# Altium schematic sheet [03] - 28PINS SCHEMATIC.SchDoc("[03] - 28PINS SCHEMATIC")
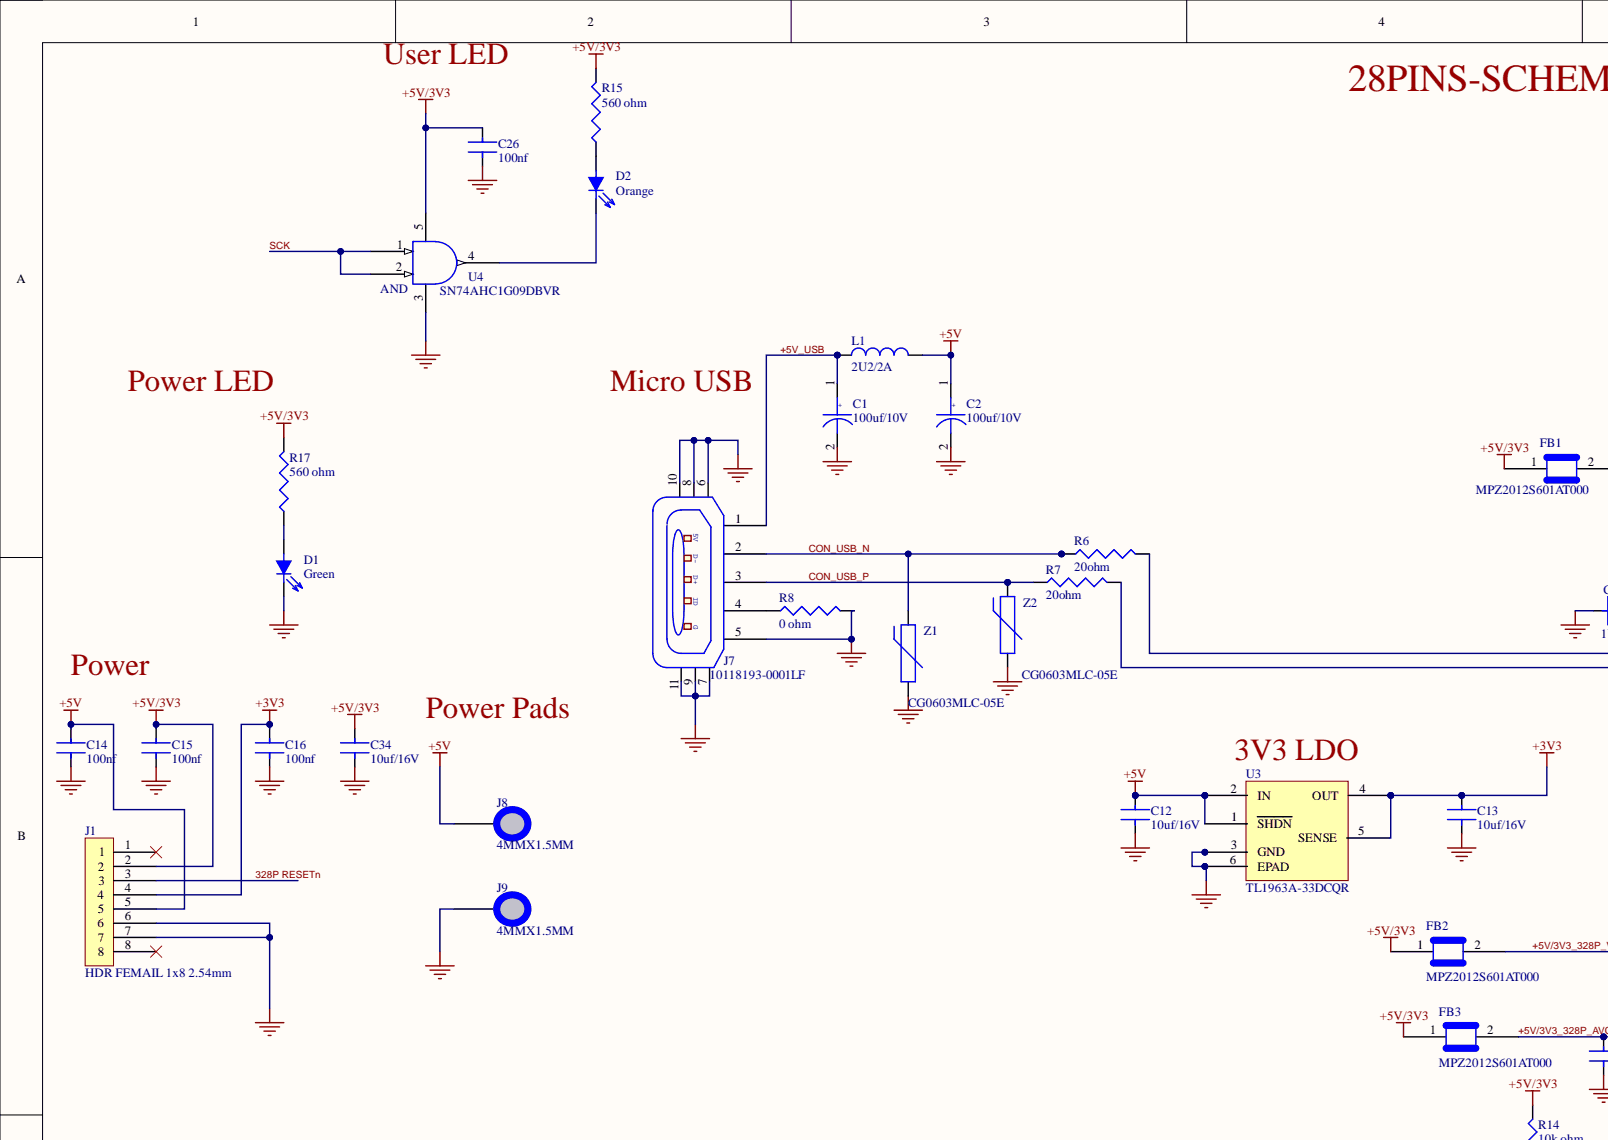
[diagram p0: [03] - 28PINS SCHEMATIC.SchDoc, top left]
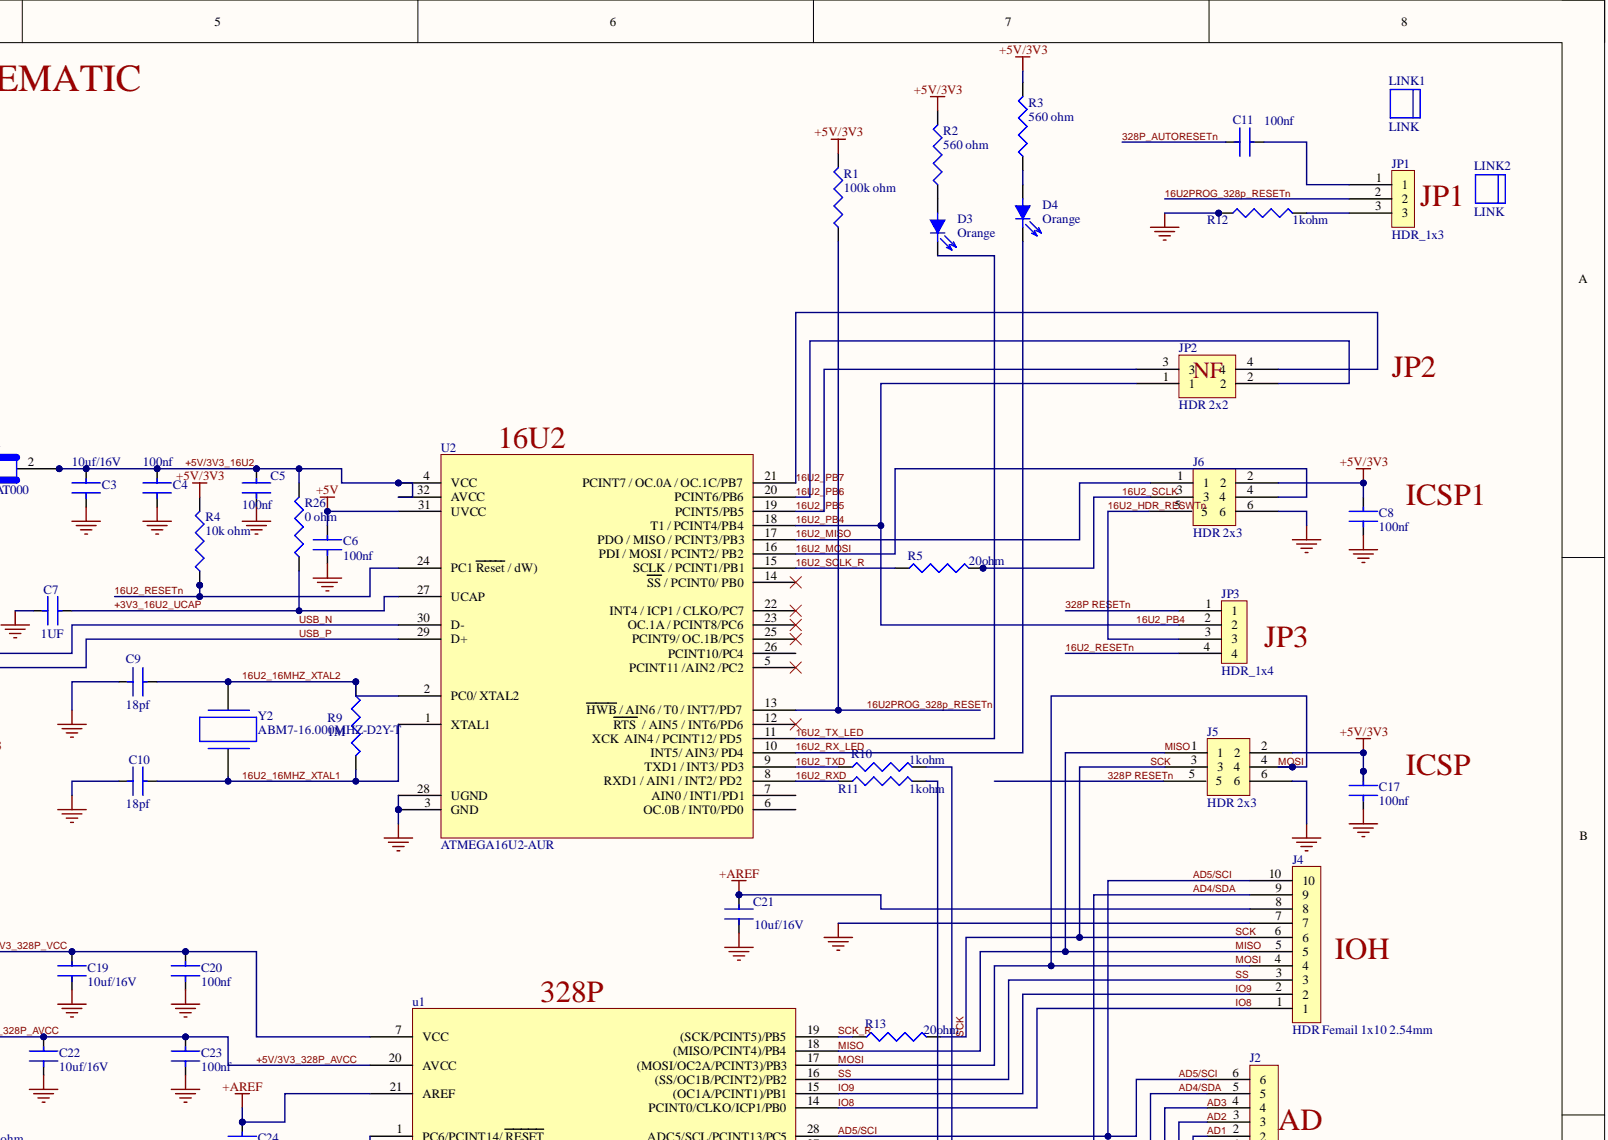
[diagram p1: [03] - 28PINS SCHEMATIC.SchDoc, top right]
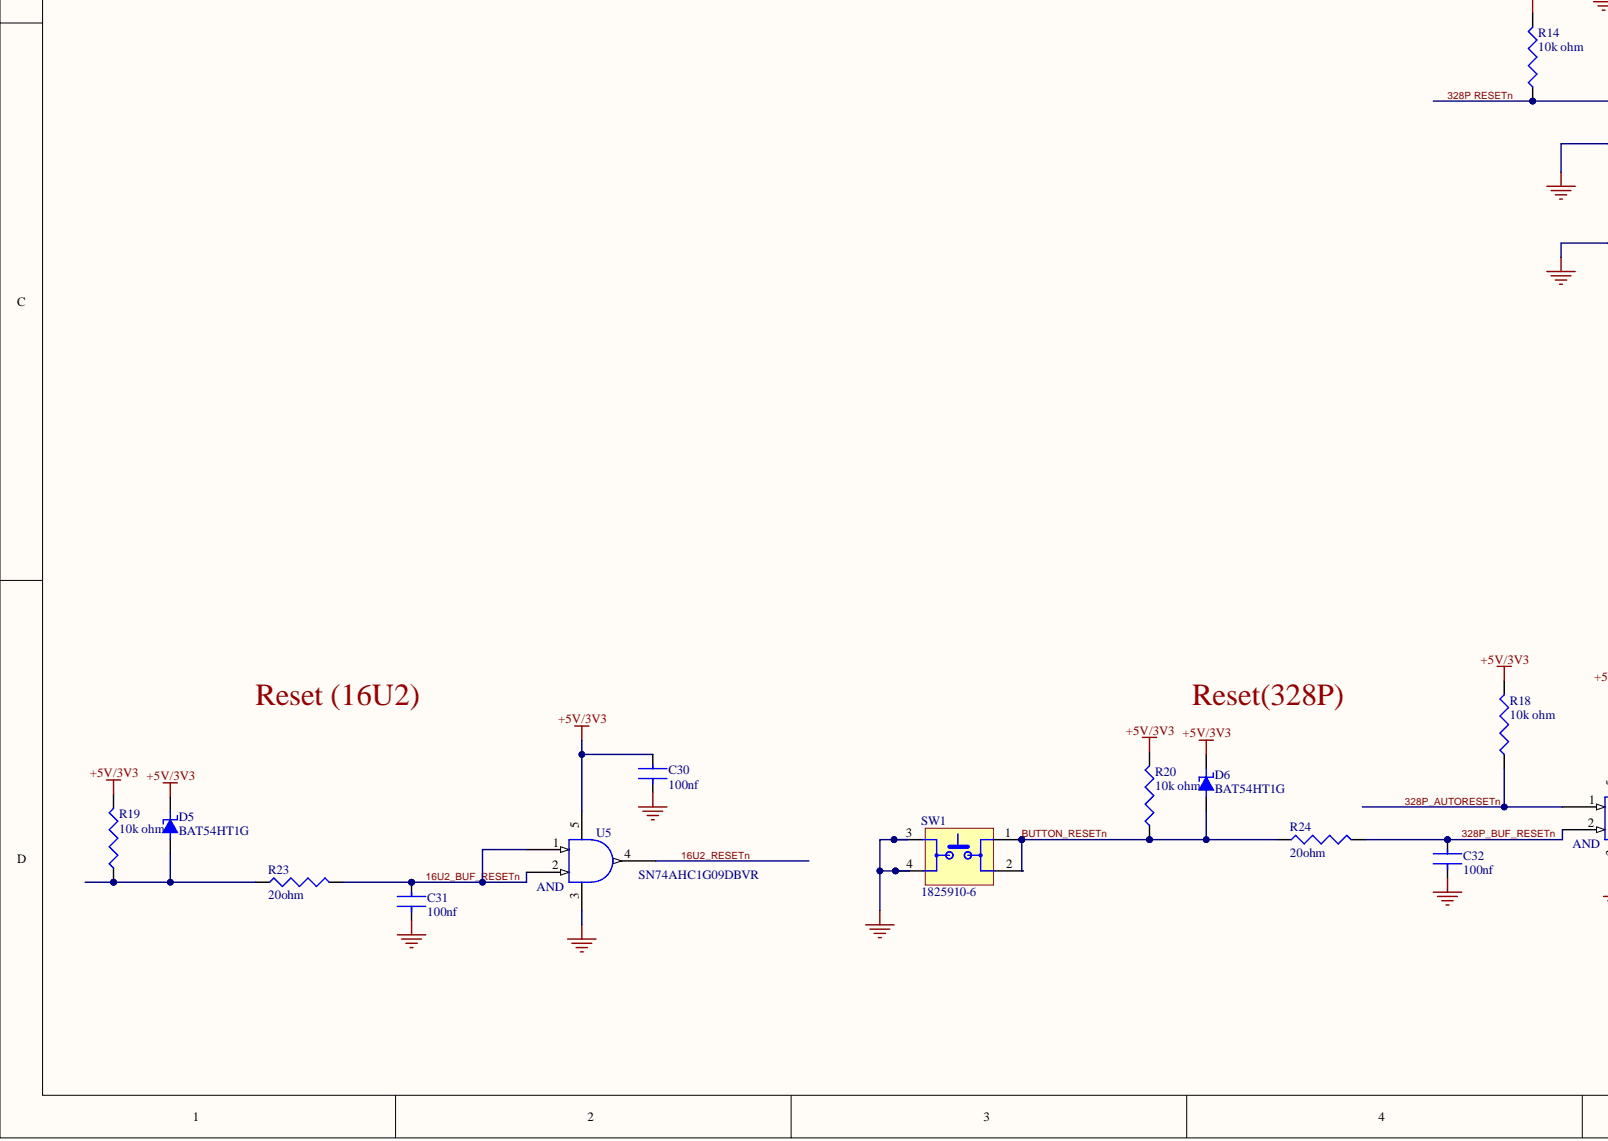
[diagram p2: [03] - 28PINS SCHEMATIC.SchDoc, bottom left]
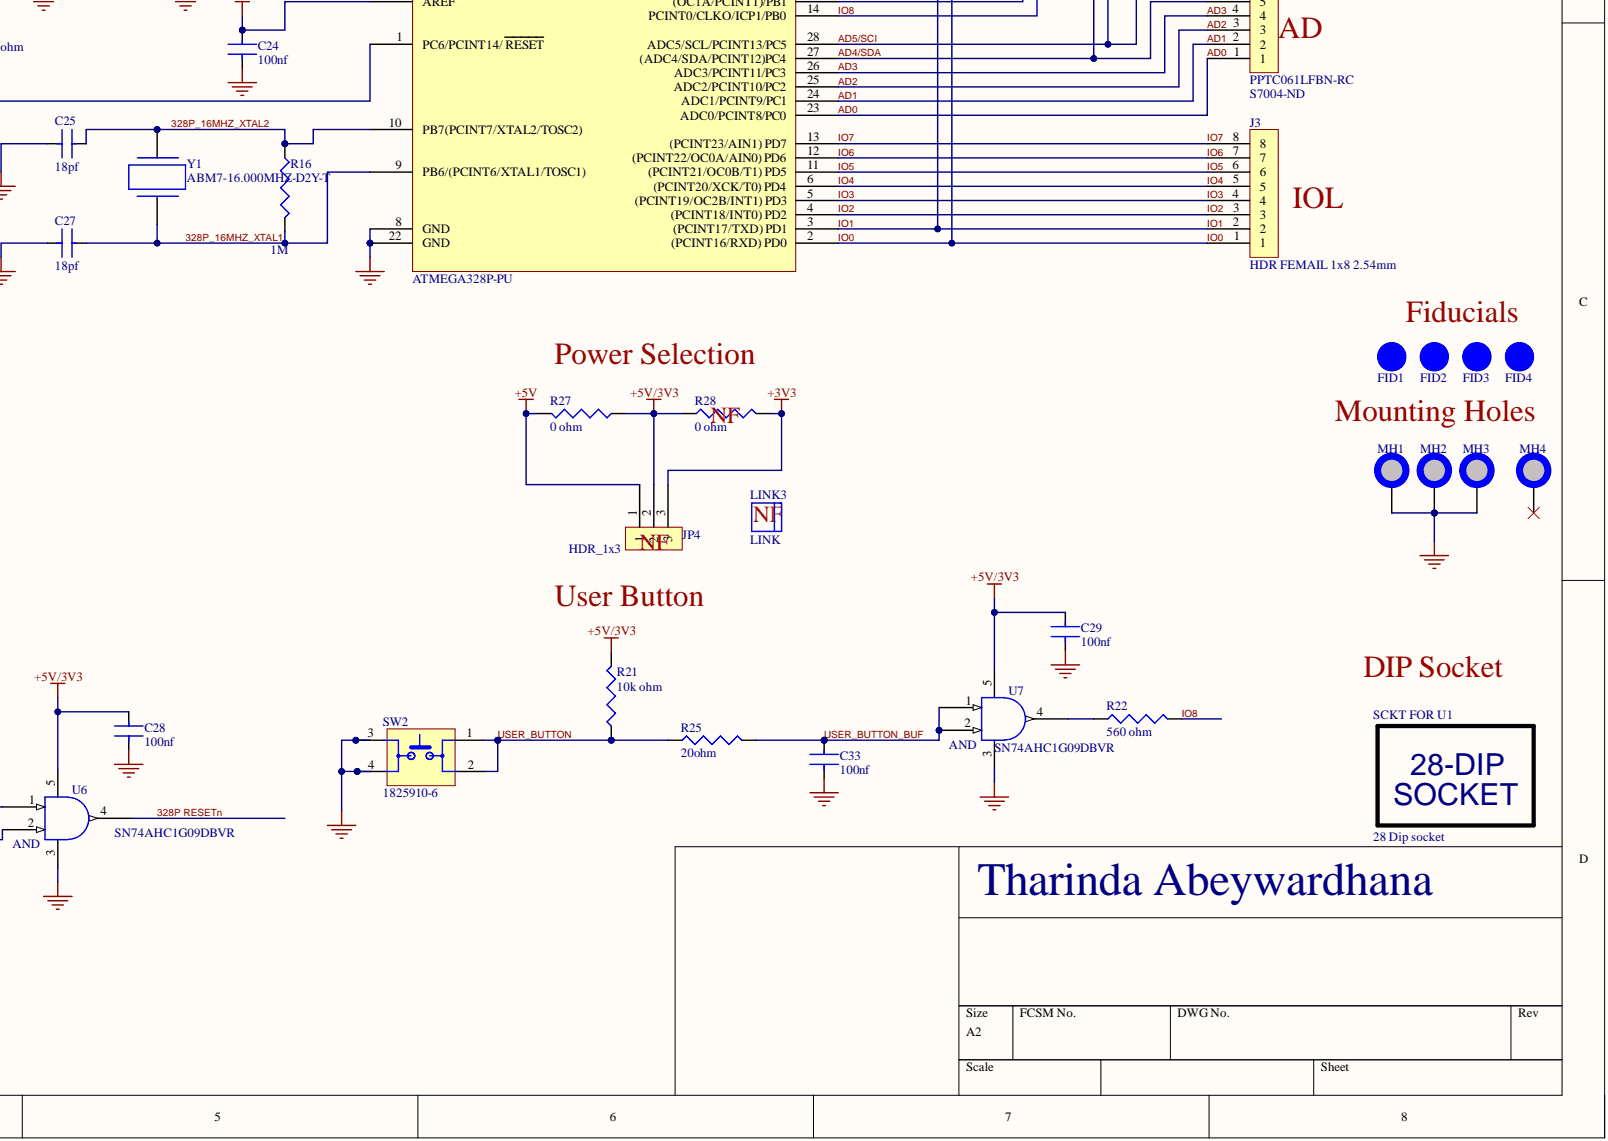
[diagram p3: [03] - 28PINS SCHEMATIC.SchDoc, bottom right]

## Parts
C1  100uf/10V  [C_ALU_C_SIZE]
C2  100uf/10V  [C_ALU_C_SIZE]
C3  10uf/16V  [C0805/10uf/16V]
C4  100nf  [C0805]
C5  100nf  [C0805]
C6  100nf  [C0805]
C7  1UF  [C0805/10uf/16V]
C8  100nf  [C0805]
C9  18pf  [C0805]
C10  18pf  [C0805]
C11  100nf  [C0805]
C12  10uf/16V  [C0805/10uf/16V]
C13  10uf/16V  [C0805/10uf/16V]
C14  100nf  [C0805]
C15  100nf  [C0805]
C16  100nf  [C0805]
C17  100nf  [C0805]
C19  10uf/16V  [C0805/10uf/16V]
C20  100nf  [C0805]
C21  10uf/16V  [C0805/10uf/16V]
C22  10uf/16V  [C0805/10uf/16V]
C23  100nf  [C0805]
C24  100nf  [C0805]
C25  18pf  [C0805]
C26  100nf  [C0805]
C27  18pf  [C0805]
C28  100nf  [C0805]
C29  100nf  [C0805]
C30  100nf  [C0805]
C31  100nf  [C0805]
C32  100nf  [C0805]
C33  100nf  [C0805]
C34  10uf/16V  [C0805/10uf/16V]
D1  Green  [LED_0603]
D2  Orange  [LED_0603]
D3  Orange  [LED_0603]
D4  Orange  [LED_0603]
D5  BAT54HT1G  [SOD323]
D6  BAT54HT1G  [SOD323]
FB1  MPZ2012S601AT000  [Ferrite_Chip]
FB2  MPZ2012S601AT000  [Ferrite_Chip]
FB3  MPZ2012S601AT000  [Ferrite_Chip]
FID1  FID_1MM  [FID_40x120D]  (value not drawn)  (no BOM)
FID2  FID_1MM  [FID_40x120D]  (value not drawn)  (no BOM)
FID3  FID_1MM  [FID_40x120D]  (value not drawn)  (no BOM)
FID4  FID_1MM  [FID_40x120D]  (value not drawn)  (no BOM)
J1  HDR FEMAIL 1x8 2.54mm  [Femailport_8_pin]
J2  PPTC061LFBN-RC  Supplier Part Number 1: S7004-ND  [Femailport_6_pin]
J3  HDR FEMAIL 1x8 2.54mm  [Femailport_8_pin]
J4  HDR Femail 1x10 2.54mm  [Hdr_Femail_1x10_2.54mm]
J5  HDR 2x3  [HDR_2x3_1.54mm]
J6  HDR 2x3  [HDR_2x3_1.54mm]
J7  10118193-0001LF  [10118193]
J8  4MMX1.5MM  [PAD_WITH_HALLS4MMX1.5MM]  (no BOM)
J9  4MMX1.5MM  [PAD_WITH_HALLS4MMX1.5MM]  (no BOM)
JP1  HDR_1x3  [Hdr_1x3_2.54mm]
JP2  HDR 2x2  [HDR_2x2_2.54mm]
JP3  HDR_1x4  [HDR_1x4_2.54mm]
JP4  HDR_1x3  [Hdr_1x3_2.54mm]
L1  2U2/2A  [C1210_IND]
LINK1  LINK  (mechanical)
LINK2  LINK  (mechanical)
LINK3  LINK  (mechanical)
MH1  MH_7.4MMC_3.2MMD  [MH_7.4MMD_3.2MMD]  (value not drawn)  (no BOM)
MH2  MH_7.4MMC_3.2MMD  [MH_7.4MMD_3.2MMD]  (value not drawn)  (no BOM)
MH3  MH_7.4MMC_3.2MMD  [MH_7.4MMD_3.2MMD]  (value not drawn)  (no BOM)
MH4  MH_7.4MMNC_3.3MMD  [MH_7.4MMD_3.2MMD_NON_COPPER_NPT]  (value not drawn)  (no BOM)
R1  100k ohm  [R0805]
R2  560 ohm  [R0805]
R3  560 ohm  [R0805]
R4  10k ohm  [R0805]
R5  20ohm  [R0805]
R6  20ohm  [R0805]
R7  20ohm  [R0805]
R8  0 ohm  [R0805]
R9  1M  [R0805]
R10  1kohm  [R0805]
R11  1kohm  [R0805]
R12  1kohm  [R0805]
R13  20ohm  [R0805]
R14  10k ohm  [R0805]
R15  560 ohm  [R0805]
R16  1M  [R0805]
R17  560 ohm  [R0805]
R18  10k ohm  [R0805]
R19  10k ohm  [R0805]
R20  10k ohm  [R0805]
R21  10k ohm  [R0805]
R22  560 ohm  [R0805]
R23  20ohm  [R0805]
R24  20ohm  [R0805]
R25  20ohm  [R0805]
R26  0 ohm  [R0805]
R27  0 ohm  [R0805]
R28  0 ohm  [R0805]
SCKT_FOR_U1  28 Dip socket  [28_dip_7.62MM_SOCKET]
SW1  1825910-6  [1825910-6]
SW2  1825910-6  [1825910-6]
u1  ATMEGA328P-PU  [arduino_ATmega28p3]
U2  ATMEGA16U2-AUR  [TQfp32]
U3  TL1963A-33DCQR  [DCQ(R-PDSQ-CG)]
U4  SN74AHC1G09DBVR  [DBV_SOT_23_5]
U5  SN74AHC1G09DBVR  [DBV_SOT_23_5]
U6  SN74AHC1G09DBVR  [DBV_SOT_23_5]
U7  SN74AHC1G09DBVR  [DBV_SOT_23_5]
Y1  ABM7-16.000MHZ-D2Y-T  [ABM7_v1_1_BIG]
Y1
Y2  ABM7-16.000MHZ-D2Y-T  [ABM7_v1_1_BIG]
Y2
Z1  CG0603MLC-05E  [R0603]
Z2  CG0603MLC-05E  [R0603]

## Nets
+3V3: C13.2 C16.2 J1.4 JP4.3 R28.2 U3.4(OUT) U3.5(SENSE)
+5V: C2.1 C6.2 C12.2 C14.2 J1.5 J8.1 JP4.1 L1.2 R27.2 U2.31(UVCC) U3.1(SHDN) U3.2(IN)
+5V/3V3: C8.2 C15.2 C17.2 C26.2 C28.2 C29.2 C30.2 C34.2 D5.K D6.K FB1.1 FB2.1 FB3.1 J1.2 J5.2 J6.2 JP4.2 R1.2 R2.2 R3.2 R4.2 R14.2 R15.2 R17.2 R18.2 R19.2 R20.2 R21.2 R27.1 R28.1 U4.5 U5.5 U6.5 U7.5
+AREF: C21.2 C24.2 J4.8 u1.21(AREF)
GND: C1.2 C2.2 C3.1 C4.1 C5.1 C6.1 C7.1 C8.1 C9.1 C10.1 C12.1 C13.1 C14.1 C15.1 C16.1 C17.1 C19.1 C20.1 C21.1 C22.1 C23.1 C24.1 C25.1 C26.1 C27.1 C28.1 C29.1 C30.1 C31.1 C32.1 C33.1 C34.1 D1.K J1.6 J1.7 J4.7 J5.6 J6.6 J7.5 J7.6 J7.7 J7.8 J7.9 J7.10 J7.11 J9.1 MH1.1 MH2.1 MH3.1 R8.2 R12.1 SW1.3 SW1.4 SW2.3 SW2.4 u1.8(GND) u1.22(GND) U2.3(GND) U2.28(UGND) U3.3(GND) U3.6(EPAD) U4.3 U5.3 U6.3 U7.3 Z1.1 Z2.1
16U2_16MHZ_XTAL1: C10.2 R9.2 U2.1(XTAL1) Y2.1
16U2_16MHZ_XTAL2: C9.2 R9.1 U2.2(PC0/_XTAL2) Y2.2
16U2_BUF_RESETn: C31.2 R23.2 U5.1 U5.2
16U2_HDR_RESWTn: J6.5 JP3.3
16U2_MISO: J6.1 U2.17(PDO_/_MISO_/_PCINT3/PB3)
16U2_MOSI: J6.4 U2.16(PDI_/_MOSI_/_PCINT2/_PB2)
16U2_PB4: JP2.1 JP3.2 U2.18(T1_/_PCINT4/PB4)
16U2_PB5: JP2.3 U2.19(PCINT5/PB5)
16U2_PB6: JP2.2 U2.20(PCINT6/PB6)
16U2_PB7: JP2.4 U2.21(PCINT7_/_OC.0A_/_OC.1C/PB7)
16U2_RESETn: JP3.4 R4.1 U2.24(PC1_Reset_/_dW)) U5.4
16U2_RX_LED: D4.K U2.10(INT5/_AIN3/_PD4)
16U2_RXD: R11.1 U2.8(RXD1_/_AIN1_/_INT2/_PD2)
16U2_SCLK: J6.3 R5.2
16U2_SCLK_R: R5.1 U2.15(SCLK_/_PCINT1/PB1)
16U2_TX_LED: D3.K U2.11(AIN4_/_PCINT12/_PD5)
16U2_TXD: R10.1 U2.9(TXD1_/_INT3/_PD3)
16U2PROG_328p_RESETn: JP1.2 R1.1 U2.13(HWB_/_AIN6_/_T0_/_INT7/PD7)
328P RESETn: J1.3 J5.5 JP3.1 R14.1 u1.1(PC6/PCINT14/_RESET) U6.4
328P_16MHZ_XTAL1: C27.2 R16.2 u1.9(PB6/(PCINT6/XTAL1/TOSC1)) Y1.1
328P_16MHZ_XTAL2: C25.2 R16.1 u1.10(PB7(PCINT7/XTAL2/TOSC2)) Y1.2
328P_AUTORESETn: C11.1 R18.1 U6.1
328P_BUF_RESETn: C32.2 R24.2 U6.2
+3V3_16U2_UCAP: C7.2 R26.1 U2.27(UCAP)
+5V/3V3_16U2: C3.2 C4.2 C5.2 FB1.2 R26.2 U2.4(VCC) U2.32(AVCC)
+5V/3V3_328P_AVCC: C22.2 C23.2 FB3.2 u1.20(AVCC)
+5V/3V3_328P_VCC: C19.2 C20.2 FB2.2 u1.7(VCC)
+5V_USB: C1.1 J7.1 L1.1
AD0: J2.1 u1.23(ADC0/PCINT8/PC0)
AD1: J2.2 u1.24(ADC1/PCINT9/PC1)
AD2: J2.3 u1.25(ADC2/PCINT10/PC2)
AD3: J2.4 u1.26(ADC3/PCINT11/PC3)
AD4/SDA: J2.5 J4.9 u1.27((ADC4/SDA/PCINT12)PC4)
AD5/SCI: J2.6 J4.10 u1.28(ADC5/SCL/PCINT13/PC5)
BUTTON_RESETn: D6.A R20.1 R24.1 SW1.1 SW1.2
CON_USB_N: J7.2 R6.1 Z1.2
CON_USB_P: J7.3 R7.1 Z2.2
IO0: J3.1 R10.2 u1.2((PCINT16/RXD)_PD0)
IO1: J3.2 R11.2 u1.3((PCINT17/TXD)_PD1)
IO2: J3.3 u1.4((PCINT18/INT0)_PD2)
IO3: J3.4 u1.5((PCINT19/OC2B/INT1)_PD3)
IO4: J3.5 u1.6((PCINT20/XCK/T0)_PD4)
IO5: J3.6 u1.11((PCINT21/OC0B/T1)_PD5)
IO6: J3.7 u1.12((PCINT22/OC0A/AIN0)_PD6)
IO7: J3.8 u1.13((PCINT23/AIN1)_PD7)
IO8: J4.1 R22.2 u1.14(PCINT0/CLKO/ICP1/PB0)
IO9: J4.2 u1.15((OC1A/PCINT1)/PB1)
MISO: J4.5 J5.1 u1.18((MISO/PCINT4)/PB4)
MOSI: J4.4 J5.4 u1.17((MOSI/OC2A/PCINT3)/PB3)
SCK: J4.6 J5.3 R13.2 U4.1 U4.2
SCK_R: R13.1 u1.19((SCK/PCINT5)/PB5)
SS: J4.3 u1.16((SS/OC1B/PCINT2)/PB2)
USB_N: R6.2 U2.30(D-)
USB_P: R7.2 U2.29(D+)
USER_BUTTON: R21.1 R25.1 SW2.1 SW2.2
USER_BUTTON_BUF: C33.2 R25.2 U7.1 U7.2
NetC11_2: C11.2 JP1.1
NetD1_A: D1.A R17.1
NetD2_A: D2.A R15.1
NetD2_K: D2.K U4.4
NetD3_A: D3.A R2.1
NetD4_A: D4.A R3.1
NetD5_A: D5.A R19.1 R23.1
NetJ7_4: J7.4 R8.1
NetJP1_3: JP1.3 R12.2
NetR22_1: R22.1 U7.4

Not connected: J1 1 8; MH4 1; U2 5(PCINT11_/AIN2_/PC2) 6(OC.0B_/_INT0/PD0) 7(AIN0_/_INT1/PD1) 12(/_AIN5_/_INT6/PD6) 14(SS_/_PCINT0/_PB0) 22(INT4_/_ICP1_/_CLKO/PC7) 23(OC.1A_/_PCINT8/PC6) 25(PCINT9/_OC.1B/PC5) 26(PCINT10/PC4)
